# Altium schematic sheet BMS Carrier - AFE Interface.SchDoc("BMS Carrier - AFE Interface")
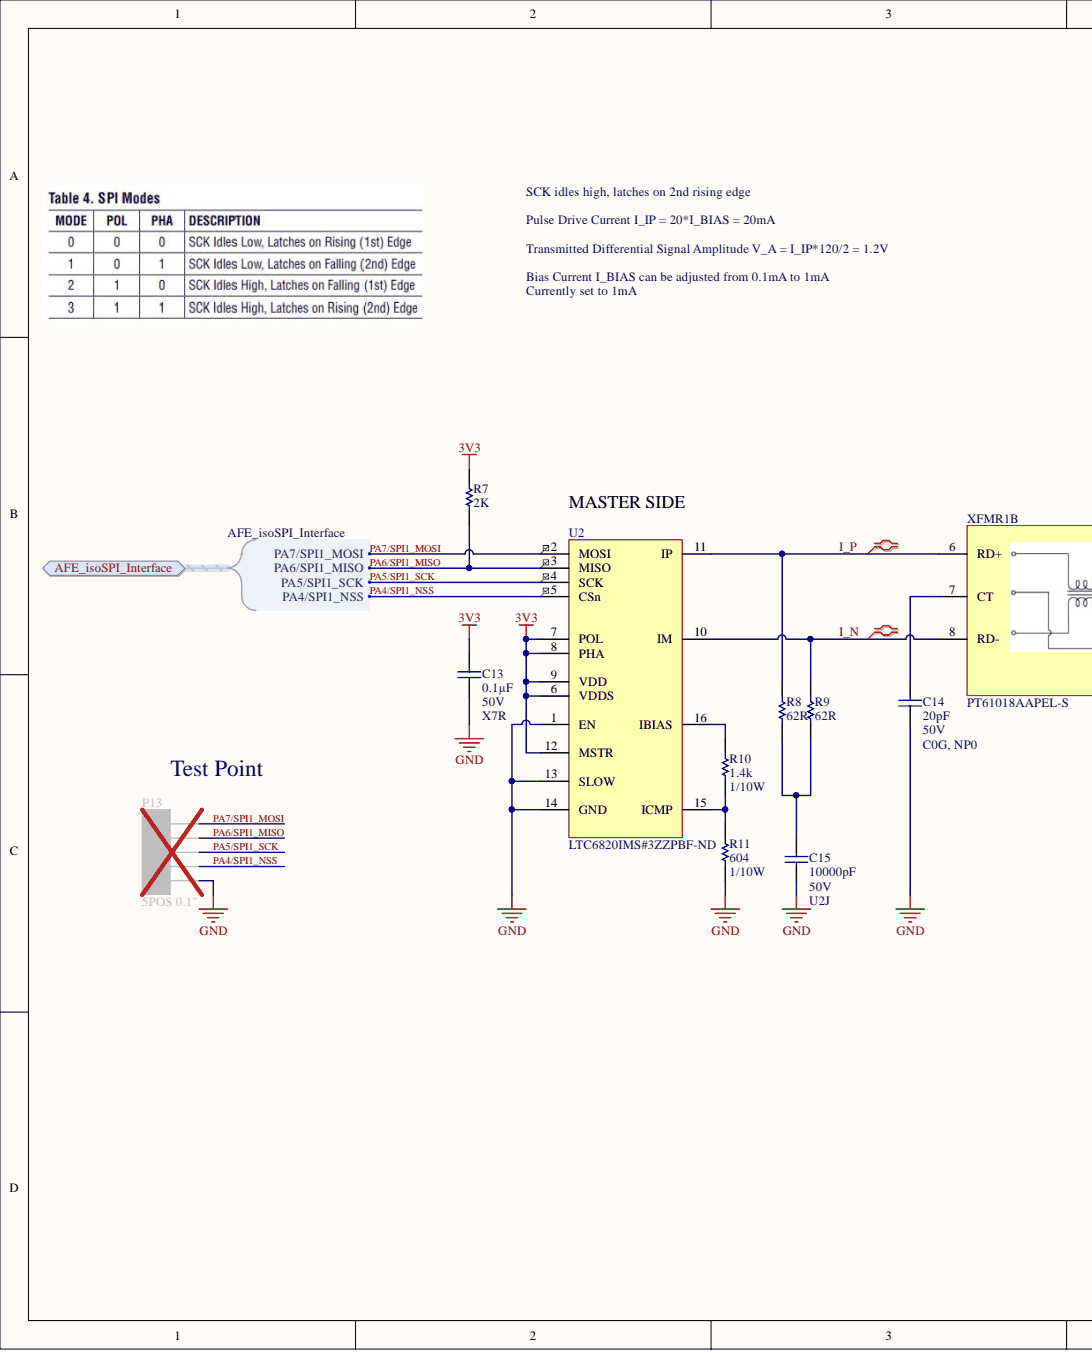
[diagram p0: BMS Carrier - AFE Interface.SchDoc, left]
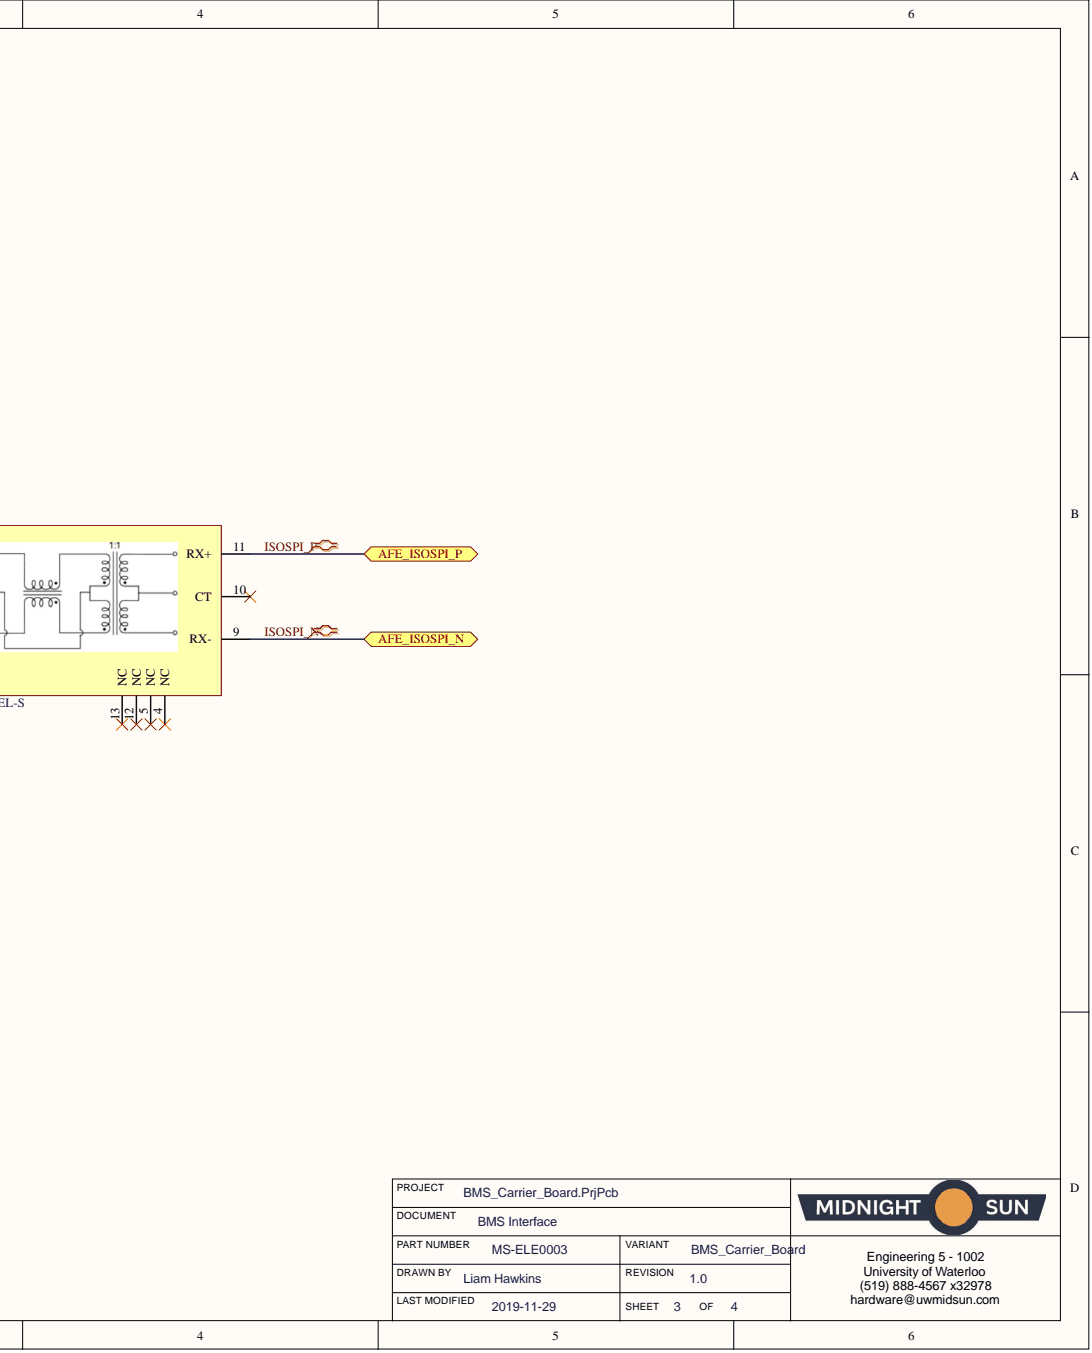
[diagram p1: BMS Carrier - AFE Interface.SchDoc, right]

## Parts
C13  Voltage: 50V  Temperature Coefficient: X7R  Capacitance: 0.1µF  [CAP, 0603]  (hidden comment: CAP CER 0.1UF 50V 10% X7R 0603)
C14  Voltage: 50V  Capacitance: 20pF  [CAP, 0603]  (hidden comment: CAP CER 20PF 50V ±5% C0G/NP0 0603)
C15  Voltage: 50V  Temperature Coefficient: U2J  Capacitance: 10000pF  [CAP, 0603]  (hidden comment: CAP CER 10nF 50V 5% X7R 0603)
P13  5POS 0.1"  [CONN, 5POS HEADR 0.1"]
R7  2K  [RES, 0603]
R8  62R  [RES, 0603]
R9  62R  [RES, 0603]
R10  Resistance: 1.4k  Power: 1/10W  [RES, 0603]  (hidden comment: RES 1.4k OHM 1% 1/10W 0603)
R11  Power: 1/10W  [RES, 0603]  (hidden comment: RES 604 OHM 1% 1/10W 0603)
U2  Supplier Part Number 1: LTC6820IMS#3ZZPBF-ND  [IC isoSPI Interface LTC6820 MSOP]  (hidden comment: IC ISOSPI COMM INTERFACE LTC6820IMS#3ZZPBF)
XFMR1  MFG_PN: PT61018AAPEL-S  [IC, PULSE XFMR 1CT:1CT 350UH SMD]  (hidden comment: IC PULSE XFMR 1CT:1CT 350UH SMD)  (part B)

## Ports
AFE_isoSPI_Interface, AFE_isoSPI_Interface.PA4/SPI1_NSS, AFE_isoSPI_Interface.PA5/SPI1_SCK, AFE_isoSPI_Interface.PA6/SPI1_MISO, AFE_isoSPI_Interface.PA7/SPI1_MOSI, AFE_ISOSPI_N, AFE_ISOSPI_P

## Nets
3V3: C13.1 R7.1 U2.6(VDDS) U2.7(POL) U2.8(PHA) U2.9(VDD) U2.12(MSTR)
GND: C13.2 C14.2 C15.2 P13.5 R11.2 U2.1(EN) U2.13(SLOW) U2.14(GND)
I_N: R9.1 U2.10(IM) XFMR1.8(RD-)
I_P: R8.1 U2.11(IP) XFMR1.6(RD+)
ISOSPI_N: XFMR1.9(RX-) ; port AFE_ISOSPI_N
ISOSPI_P: XFMR1.11(RX+) ; port AFE_ISOSPI_P
PA4/SPI1_NSS: P13.4 U2.5(CSn) ; port AFE_isoSPI_Interface
PA5/SPI1_SCK: P13.3 U2.4(SCK) ; port AFE_isoSPI_Interface
PA6/SPI1_MISO: P13.2 R7.2 U2.3(MISO) ; port AFE_isoSPI_Interface
PA7/SPI1_MOSI: P13.1 U2.2(MOSI) ; port AFE_isoSPI_Interface
NetC14_1: C14.1 XFMR1.7(CT)
NetC15_1: C15.1 R8.2 R9.2
NetR10_1: R10.1 U2.16(IBIAS)
NetR10_2: R10.2 R11.1 U2.15(ICMP)

Not connected: XFMR1 4(NC) 5(NC) 10(CT) 12(NC) 13(NC)

## Library data of the parts
C13 = CAP CER 0.1UF 50V 10% X7R 0603: 0.10µF ±10% 50V Ceramic Capacitor X7R 0603 (1608 Metric); Manufacturer Part Number 1: 06035C104KAT2A; Manufacturer 1: AVX Corporation; Tolerance: ±10%; Thickness (Max): 0.035" (0.90mm); Supplier Part Number 1: 478-5052-1-ND; Supplier 1: Digi-Key; Size / Dimension: 0.063" L x 0.032" W (1.60mm x 0.81mm); Ratings: -; Part Status: Active; Packaging: Cut Tape (CT); Package / Case: 0603 (1608 Metric); Operating Temperature: -55°C ~ 125°C; Mounting Type: Surface Mount, MLCC; Lead Style: -; Lead Spacing: -; Height - Seated (Max): -; ComponentLink1URL: http://datasheets.avx.com/X7RDielectric.pdf; ComponentLink1Description: http://datasheets.avx.com/X7RDielectric.pdf; Component Kind: Standard; Library Name: Schematic Diagrams.SchLib
C14 = CAP CER 20PF 50V ±5% C0G/NP0 0603: 20pF ±5% 50V Ceramic Capacitor C0G, NP0 0603 (1608 Metric); Manufacturer Part Number 1: GRM1885C1H200JA01D; Manufacturer 1: Murata Electronics North America; Tolerance: ±5%; Thickness (Max): 0.035" (0.90mm); Temperature Coefficient: C0G, NP0; Supplier Part Number 1: 490-1410-1-ND; Supplier 1: Digi-Key; Size / Dimension: 0.063" L x 0.031" W (1.60mm x 0.80mm); Ratings: -; Part Status: Active; Packaging: Cut Tape (CT); Package / Case: 0603 (1608 Metric); Operating Temperature: -55°C ~ 125°C; Mounting Type: Surface Mount, MLCC; Lead Style: -; Lead Spacing: -; Height - Seated (Max): -; ComponentLink1URL: http://www.murata.com/~/media/webrenewal/support/library/catalog/products/capacitor/mlcc/c02e.ashx; ComponentLink1Description: http://www.murata.com/~/media/webrenewal/support/library/catalog/products/capacitor/mlcc/c02e.ashx; Component Kind: Standard; Library Name: Schematic Diagrams.SchLib
C15 = CAP CER 10nF 50V 5% X7R 0603: 10000pF ±5% 50V Ceramic Capacitor U2J 0603 (1608 Metric); Manufacturer Part Number 1: C0603C103J5JAC7867; Manufacturer 1: KEMET; Tolerance: ±5%; Thickness (Max): 0.034" (0.87mm); Supplier Part Number 1: 399-13384-1-ND; Supplier 1: Digi-Key; Size / Dimension: 0.063" L x 0.032" W (1.60mm x 0.80mm); Ratings: -; Part Status: Active; Packaging: Cut Tape (CT); Package / Case: 0603 (1608 Metric); Operating Temperature: -55°C ~ 125°C; Mounting Type: Surface Mount, MLCC; Lead Style: -; Lead Spacing: -; Height - Seated (Max): -; Failure Rate: -; ComponentLink1URL: http://www.kemet.com/docfinder?Partnumber=C0603C103J5JAC7867; ComponentLink1Description: http://www.kemet.com/docfinder?Partnumber=C0603C103J5JAC7867; Component Kind: Standard; Library Name: Schematic Diagrams.SchLib
P13 = CONN 5POS HEADR MALE 0.1": Manufacturer Part Number 1: 0022284050; Manufacturer 1: Molex; Pitch: 2.54mm; Number of Positions: 5; Max Voltage Rating (DC): 250V; Gender: Male; Description 1: Conn Unshrouded Header HDR 5 POS 2.54mm Solder ST Thru-Hole KK® Bag; Contact Resistance: 20mR; Connector Type: Male Pin; Termination: Solder; Supplier Part Number 1: WM50014-05-ND; Supplier 1: Digi-Key; Stock 1: 3161; Pricing 1: 1=0.22, 10=0.21, 25=0.1796, 50=0.157, 100=0.1459, 250=0.129, 500=0.1234 (USD); Packaging: Bulk; Orientation: Straight; Number of Rows: 1; Number of Contacts: 5; Mount: Through Hole; Min Operating Temperature: 0°C; Max Voltage Rating (AC): 250V; Max Operating Temperature: 75°C; Length: 12.7mm; Housing Material: Nylon; Housing Colour: Black; Depth: 2.49mm; Contact Plating: Nickel,Tin; Contact Mating Length: 0.24inch; Contact Material: Bronze,Brass; ComponentLink3URL: https://datasheet.ciiva.com/15217/getdatasheetpartid-256138-15217709.pdf; ComponentLink3Description: https://datasheet.ciiva.com/15217/getdatasheetpartid-256138-15217709.pdf; ComponentLink2URL: https://datasheet.ciiva.com/14804/179390022284050-pcb-headers-14804361.pdf; ComponentLink2Description: https://datasheet.ciiva.com/14804/179390022284050-pcb-headers-14804361.pdf; ComponentLink1URL: https://datasheet.ciiva.com/6814/022284363-sd-6814049.pdf; ComponentLink1Description: https://datasheet.ciiva.com/6814/022284363-sd-6814049.pdf; Component Kind: Standard; Colour: Black; Library Name: Schematic Diagrams.SchLib
R7 = RES 2K OHM 1% 1/10W 0603: 2k Ohm ±1% 0.1W, 1/10W Chip Resistor 0603 (1608 Metric) Moisture Resistant Thick Film; Manufacturer Part Number: RC0603FR-072KL; Manufacturer: Yageo; Temperature Coefficient: ±100ppm/°C; Tolerance: ±1%; Supplier Part Number 1: 311-2.00KHRCT-ND; Supplier Device Package: 0603; Supplier 1: Digi-Key; Stock 1: 1750701; Size / Dimension: 0.063" L x 0.031" W (1.60mm x 0.80mm); RoHS: RoHS Compliant; Resistance (Ohms): 2k; Pricing 1: 1=0.15, 10=0.023, 100=0.0093, 1000=0.00415, 2500=0.0036 (CAD); Power (Watts): 0.1W, 1/10W; Part Status: Active; Packaging: Cut Tape (CT); Package / Case: 0603 (1608 Metric); Operating Temperature: -55°C ~ 155°C; Height - Seated (Max): 0.022" (0.55mm); Features: Moisture Resistant; Failure Rate: -; Composition: Thick Film; ComponentLink1URL: http://www.yageo.com/documents/recent/PYu-RC_Group_51_RoHS_L_7.pdf; ComponentLink1Description: http://www.yageo.com/documents/recent/PYu-RC_Group_51_RoHS_L_7.pdf; Component Kind: Standard; Category: Resistors; Library Name: Schematic Diagrams.SchLib
R8 R9 = RES 62 OHM 0.1% 1/10W 0603: 62 Ohms ±0.1% 0.1W, 1/10W Chip Resistor 0603 (1608 Metric) Automotive AEC-Q200 Thin Film; Manufacturer Part Number: ERA-3AEB620V; Manufacturer: Panasonic Electronic Components; Temperature Coefficient: ±25ppm/°C; Tolerance: ±0.1%; Supplier Part Number 1: P62DBCT-ND; Supplier Device Package: 0603; Supplier 1: Digi-Key; Stock 1: 10320; Size / Dimension: 0.063" L x 0.032" W (1.60mm x 0.80mm); RoHS: RoHS Compliant; Pricing 1: 1=0.52, 10=0.447, 100=0.1748, 1000=0.07317, 2500=0.06708 (CAD); Power (Watts): 0.1W, 1/10W; Part Status: Active; Packaging: Cut Tape (CT); Package / Case: 0603 (1608 Metric); Operating Temperature: -55°C ~ 155°C; Height - Seated (Max): 0.022" (0.55mm); Features: Automotive AEC-Q200; Failure Rate: -; Composition: Thin Film; ComponentLink1URL: https://industrial.panasonic.com/cdbs/www-data/pdf/RDM0000/AOA0000C307.pdf; ComponentLink1Description: https://industrial.panasonic.com/cdbs/www-data/pdf/RDM0000/AOA0000C307.pdf; Component Kind: Standard; Category: Resistors; Library Name: Schematic Diagrams.SchLib
R10 = RES 1.4k OHM 1% 1/10W 0603: RES SMD 1.4K OHM 1% 1/10W 0603; Manufacturer Part Number 1: RC0603FR-071K4L; Manufacturer 1: Yageo; Temperature Coefficient: ±100ppm/°C; Tolerance: ±1%; Supplier Part Number 1: 311-1.40KHRCT-ND; Supplier Device Package: 0603; Supplier 1: Digi-Key; Size / Dimension: 0.063" L x 0.031" W (1.60mm x 0.80mm); Part Status: Active; Packaging: Cut Tape (CT); Package / Case: 0603 (1608 Metric); Operating Temperature: -55°C ~ 155°C; Height - Seated (Max): 0.022" (0.55mm); Failure Rate: -; Composition: Thick Film; ComponentLink1URL: http://www.yageo.com/documents/recent/PYu-RC_Group_51_RoHS_L_7.pdf; ComponentLink1Description: http://www.yageo.com/documents/recent/PYu-RC_Group_51_RoHS_L_7.pdf; Component Kind: Standard; Library Name: Schematic Diagrams.SchLib
R11 = RES 604 OHM 1% 1/10W 0603: RES SMD 604 OHM 1% 1/10W 0603; Manufacturer Part Number 1: RC0603FR-07604RL; Manufacturer 1: Yageo; Temperature Coefficient: ±100ppm/°C; Resistance: 604; Tolerance: ±1%; Supplier Part Number 1: 311-604HRCT-ND; Supplier Device Package: 0603; Supplier 1: Digi-Key; Size / Dimension: 0.063" L x 0.031" W (1.60mm x 0.80mm); Part Status: Active; Packaging: Cut Tape (CT); Package / Case: 0603 (1608 Metric); Operating Temperature: -55°C ~ 155°C; Height - Seated (Max): 0.022" (0.55mm); Failure Rate: -; Composition: Thick Film; ComponentLink1URL: http://www.yageo.com/documents/recent/PYu-RC_Group_51_RoHS_L_7.pdf; ComponentLink1Description: http://www.yageo.com/documents/recent/PYu-RC_Group_51_RoHS_L_7.pdf; Component Kind: Standard; Library Name: Schematic Diagrams.SchLib
U2 = IC ISOSPI COMM INTERFACE LTC6820IMS#3ZZPBF: IC COMM INTERFACE ISOSPI 16-MSOP; Manufacturer: Linear Technology; Voltage - Supply: 2.7 V ~ 5.5 V; Interface: SPI; Supplier Device Package: 16-Lead Plastic MSOP; Supplier 1: Digi-Key; RoHS: RoHS Compliant; Part Status: Active; Packaging: Tube; Package / Case: 16-Lead Plastic MSOP; Mounting Type: Surface Mount; Manufacturer Part Number: LTC6820IMS#3ZZPBF; ComponentLink1URL: http://www.linear.com/docs/42317; ComponentLink1Description: http://www.linear.com/docs/42317; Component Kind: Standard; Category: Integrated Circuits (ICs); Applications: Isolated Communications Interface; Library Name: Schematic Diagrams.SchLib
XFMR1 = IC PULSE XFMR 1CT:1CT 350UH SMD: PULSE XFMR 1CT:1CT 350UH SMD; Manufacturer: Bourns Inc.; Isolation Voltage: 1.5kVrms; Supplier Part Number 1: PT61018AAPEL-SCT-ND; Supplier_PN: PT61018AAPEL-SCT-ND; Supplier 1: Digi-Key; Supplier: Digikey; Inductance: 350uH; Component Kind: Standard; Library Name: Schematic Diagrams.SchLib
